# Altium schematic sheet acoustic_mic_gaze.SchDoc
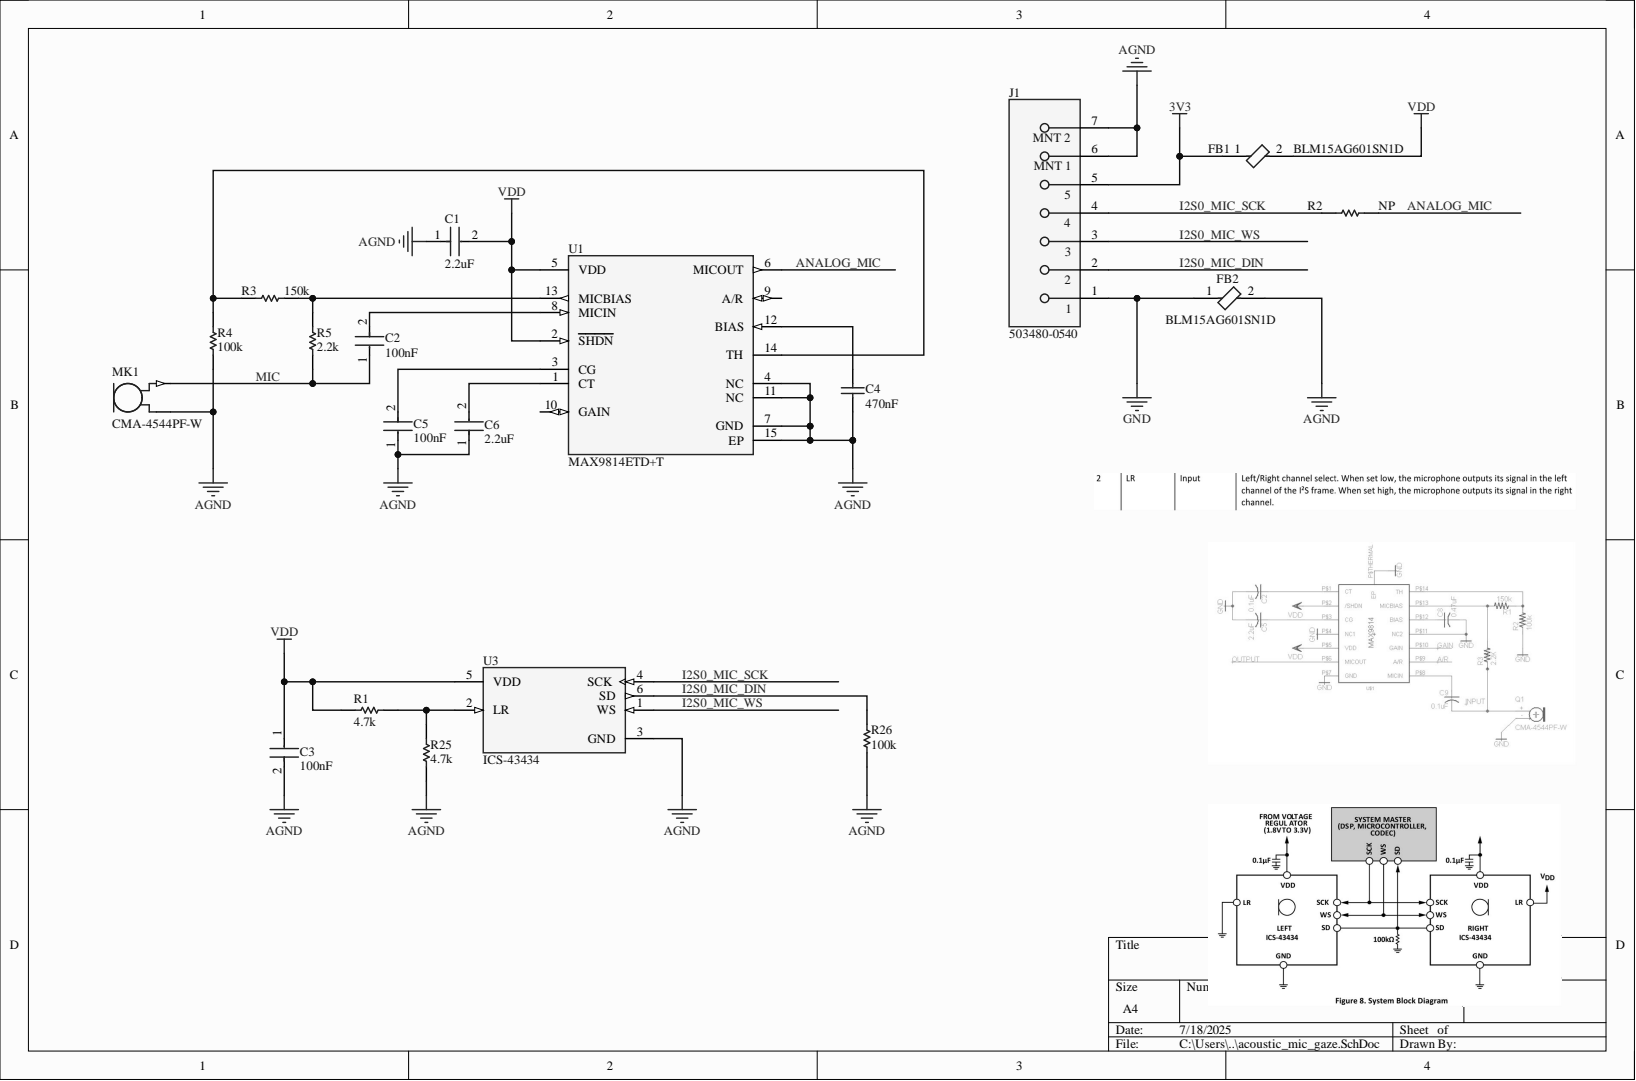
[diagram p0: acoustic_mic_gaze.SchDoc]

## Parts
C1  Capacitance: 2.2uF  [FP-GRM155-0_05-MFG]  (hidden comment: GRM155R61A225KE95D)
C2  value 100nF  [FP-GRM15-MFG]  (hidden comment: GRM155R71C104KA88D)
C3  Capacitance: 100nF  [FP-GRM15-MFG]  (hidden comment: GRM155R71C104KA88D)
C4  value 470nF  [CAPC1005X70X10LL20T00]  (hidden comment: TACK474M010QTA)
C5  value 100nF  [FP-GRM15-MFG]  (hidden comment: GRM155R71C104KA88D)
C6  Capacitance: 2.2uF  [FP-GRM155-0_05-IPC_C]  (hidden comment: GRM155R61A225KE95D)
FB1  BLM15AG601SN1D  [FP-BLM15-0_05-IPC_B]
FB2  BLM15AG601SN1D  [FP-BLM15-0_05-IPC_B]
J1  503480-0540  [FP-503480-0540-MFG]
MK1  CMA-4544PF-W  [MIC_CMA-4544PF-W]
R1  value 4.7k  [RESC1005X40X25LL05T10]  (hidden comment: CRCW04024K70FKEDHP)
R2  value NP  [RESC1005X40X25NL05T10]  (hidden comment: ERJ-U020R00X)
R3  value 150k  [RESC1005X40X25NL05T05]  (hidden comment: CRCW0402150KFKED)
R4  value 100k  [RESC1005X40X25NL05T10]  (hidden comment: RC0402FR-07100KL)
R5  value 2.2k  [RESC1005X40X25NL05T10]  (hidden comment: RC0402FR-072K2L)
R25  value 4.7k  [RESC1005X40X25LL05T10]  (hidden comment: CRCW04024K70FKEDHP)
R26  value 100k  [RESC1005X40X25NL05T10]  (hidden comment: RC0402FR-07100KL)
U1  MAX9814ETD+T  [FP-T1433-2-MFG]
U3  ICS-43434  [FP-ICS-43434-MFG]

## Nets
3V3: FB1.1 J1.5
AGND: C1.1 C3.2 C4.1 C5.1 C6.1 FB2.2 J1.6 J1.7 MK1.2 R4.2 R25.1 R26.2 U1.4(NC) U1.7(GND) U1.11(NC) U1.15(EP) U3.3(GND)
GND: FB2.1 J1.1
VDD: C1.2 C3.1 FB1.2 R1.2 U1.2(SHDN) U1.5(VDD) U3.5(VDD)
ANALOG_MIC: R2.2 U1.6(MICOUT)
I2S0_MIC_DIN: J1.2 R26.1 U3.6(SD)
I2S0_MIC_SCK: J1.4 R2.1 U3.4(SCK)
I2S0_MIC_WS: J1.3 U3.1(WS)
MIC: C2.1 MK1.1 R5.1
NetC2_2: C2.2 U1.8(MICIN)
NetC4_2: C4.2 U1.12(BIAS)
NetC5_2: C5.2 U1.3(CG)
NetC6_2: C6.2 U1.1(CT)
NetR1_1: R1.1 R25.2 U3.2(LR)
NetR3_1: R3.1 R5.2 U1.13(MICBIAS)
NetR3_2: R3.2 R4.1 U1.14(TH)

Not connected: U1 9(A/R) 10(GAIN)

## Library data of the parts
C1 C6 = CMP-06035-063009-1: CAP CER 2.2UF 10V X5R 0402; Material: Ceramic; Tolerance: 10%; Case Code (Imperial): 0402; Case Code (Metric): 1005; Case/Package: 0402; Component Kind: Standard; Depth: 0.5mm; Dielectric: X5R; Height: 0.5mm; Lead Free: Lead Free; Length: 1mm; Library Name: Altium Content Vault; Max Operating Temperature: 85°C; Min Operating Temperature: -55°C; Mount: Surface Mount; Package Quantity: 10000; Packaging: Tape and Reel; REACH SVHC: No SVHC; RoHS Compliant: Yes; Termination: SMD/SMT; Thickness: 0.022inch; Voltage Rating: 10V; Voltage Rating (DC): 10V; Weight: 0.000212oz; Width: 0.5mm
C2 C5 = CMP-2100-03641-2: CAP CER 0.1UF 16V X7R 0402; Manufacturer: Murata; Capacitance: 0.10μF; Material: Ceramic; Standoff Height: 0mm; Tolerance: 10%; Voltage Rating: 16Vdc; Category: Cap; Component Kind: Standard; ComponentLink2Description: Datasheet; ComponentLink2URL: https://search.murata.co.jp/Ceramy/image/img/A01X/G101/ENG/GRM155R71H471KA01-01A.pdf; Device Class L1: Passive Components; Device Class L2: Capacitors; Device Class L3: Ceramic Capacitors; Digikey Description: CAP CER 0.1UF 16V X7R 0402; Height: 0.55mm; Ipc Land Pattern Name: CAPC10050X219; Lead Free: yes; Library Name: Altium Content Vault; Manufacturer Part Number: GRM155R71C104KA88D; Mouser Description: 0402 0.1 uF 16V ±10 % Tolerance X7R SMT Multilayer Ceramic Capacitor; Octopart: https://octopart.com/grm155r71c104ka88d-murata-196880; Package: GRM15; RoHS: TRUE; Temperature: -55°C to +125°C; Temperature Characteristic: X7R; Temperature Range High: +125°C; Temperature Range Low: -55°C
C3 = CMP-2100-03641-2: CAP CER 0.1UF 16V X7R 0402; Manufacturer: Murata; Material: Ceramic; Standoff Height: 0mm; Tolerance: 10%; Value: 0.10μF; Voltage Rating: 16Vdc; Category: Cap; Component Kind: Standard; ComponentLink2Description: Datasheet; ComponentLink2URL: https://search.murata.co.jp/Ceramy/image/img/A01X/G101/ENG/GRM155R71H471KA01-01A.pdf; Device Class L1: Passive Components; Device Class L2: Capacitors; Device Class L3: Ceramic Capacitors; Digikey Description: CAP CER 0.1UF 16V X7R 0402; Height: 0.55mm; Ipc Land Pattern Name: CAPC10050X219; Lead Free: yes; Library Name: Altium Content Vault; Manufacturer Part Number: GRM155R71C104KA88D; Mouser Description: 0402 0.1 uF 16V ±10 % Tolerance X7R SMT Multilayer Ceramic Capacitor; Octopart: https://octopart.com/grm155r71c104ka88d-murata-196880; Package: GRM15; RoHS: TRUE; Temperature: -55°C to +125°C; Temperature Characteristic: X7R; Temperature Range High: +125°C; Temperature Range Low: -55°C
C4 = CMP-2008-00072-1: Manufacturer: AVX Interconnect / Elco; Component Kind: Standard; Library Name: Altium Content Vault; Part Number: TACK474M010QTA
FB1 FB2 = CMP-0686-00187-4: Chip Ferrite Bead, 0402, 600Ω @ 100MHz, 0.52Ω, 25%, 300mA; DC Resistance (DCR): 520mR; DC Resistance-Max: 600mR; Impedance: 600R; Material: Steel; Number of Circuits: 1; Shielding: Unshielded; Case Code (Imperial): 0402; Case Code (Metric): 1005; Case/Package: 0402; Component Kind: Standard; Core Material: Ferrite; Current Rating: 300mA; DC Current: 300mA; Depth: 0.55mm; Frequency: 100MHz; Height: 0.5mm; Lead Free: Lead Free; Length: 1mm; Library Name: Altium Content Vault; Max Current Rating: 300mA; Max DC Current: 300mA; Max Operating Temperature: 125°C; Military Standard: Not; Min Operating Temperature: -55°C; Number of Lines: 1; Number of Pins: 2; Package Quantity: 10000; Packaging: Tape and Reel; Radiation Hardening: No; REACH SVHC: No SVHC; RoHS Compliant: Yes; Series: BLM15AG_SN; Termination: SMD/SMT; Test Frequency: 100MHz; Tolerance: 25%; Width: 0.5mm
J1 = CMP-00257-52963780-1: 503480-0540 Connector FFC/FPC SKT 5 Position 0.5mm Solder Right Angle Surface Mount T/R; Manufacturer Lifecycle Status: ACTIVE; Actuator Material: Glass, Nylon, Polyamide; Current Rating: 500mA; Number of Circuits: 5; Pitch: 508µm; Plating: Gold; Voltage Rating: 50V; Wire/Cable Type: Straight; Component Kind: Standard; Contact Material: Bronze, Gold, Nickel; Contact Plating: Gold; Country of Origin: KR; Flammability Rating: UL94 V-0; Halogen Free: Low Halogen; Height: 2.07mm; Housing Material: Polymer; Library Name: Altium Content Vault; Lifecycle Status: Production; Max Operating Temperature: 85°C; Min Operating Temperature: -40°C; Mount: Surface Mount; NexarPartId: 52963780; Number of Contacts: 5; Number of Positions: 5; Number of Rows: 1; Orientation: Right Angle; RoHS: Compliant; Termination: Solder
MK1 = CMA-4544PF-W: 9.7 mm, Omnidirectional, PCB Mount, 3.0 Vdc, Electret Condenser Microphone; MF: Same Sky; Check_prices: https://www.snapeda.com/parts/CMA-4544PF-W/Same+Sky/view-part/?ref=eda; Component Kind: Standard; Library Name: CMA-4544PF-W.IntLib; MP: CMA-4544PF-W; Package: None; SnapEDA_Link: https://www.snapeda.com/parts/CMA-4544PF-W/Same+Sky/view-part/?ref=snap
R1 R25 = CMP-2002-03050-1: Manufacturer: Vishay Dale; Component Kind: Standard; Library Name: Altium Content Vault; Part Number: CRCW04024K70FKEDHP
R2 = CMP-2002-06457-1: Manufacturer: Panasonic; Component Kind: Standard; Library Name: Altium Content Vault; Part Number: ERJ-U020R00X
R3 = CMP-2002-08212-1: Manufacturer: Vishay Dale; Component Kind: Standard; Library Name: Altium Content Vault; Part Number: CRCW0402150KFKED
R4 R26 = CMP-2002-07343-1: Manufacturer: Yageo / Phycomp; Component Kind: Standard; Library Name: Altium Content Vault; Part Number: RC0402FR-07100KL
R5 = CMP-2002-07567-1: Manufacturer: Yageo / Phycomp; Component Kind: Standard; Library Name: Altium Content Vault; Part Number: RC0402FR-072K2L
U1 = CMP-05698-000003-1: IC AMP CLASS AB MONO 14TDFN; Load Impedance: 50R; Max Input Voltage: 5.5V; Max Output Current: 100mA; Max Power Dissipation: 1.481W; Min Supply Voltage: 2.7V; Number of Channels: 1; Operating Supply Current: 3.1mA; Power Supply Rejection Ratio (PSRR): 80dB; Voltage Gain: 60dB; Component Kind: Standard; Contact Plating: Tin; Height: 0.75mm; Lead Free: Lead Free; Length: 3.1mm; Library Name: Altium Content Vault; Max Operating Temperature: 85°C; Max Supply Voltage: 5.5V; Min Operating Temperature: -40°C; Mount: Surface Mount; Number of Pins: 14; Package Quantity: 1; Packaging: Tape and Reel; Radiation Hardening: No; REACH SVHC: No SVHC; Termination: SMD/SMT; Weight: 0.001764oz; Width: 3.1mm
U3 = CMP-03292-71346757-1: ICS-43434 Mic Omni-Directional -26dB 3.63V Rectangular Solder Pad T/R; Direction: Omnidirectional; Min Supply Voltage: 1.65V; Operating Supply Current: 550µA; Output Type: Digital; Component Kind: Standard; Height: 980µm; Length: 3.5mm; Library Name: Altium Content Vault; Lifecycle Status: Production; Max Length: 3.6mm; Max Operating Temperature: 85°C; Max Supply Voltage: 3.63V; Max Thickness: 1.08mm; Max Width: 2.75mm; Min Length: 3.4mm; Min Operating Temperature: -40°C; Min Thickness: 880µm; Min Width: 2.55mm; NexarPartId: 71346757; RoHS: Compliant; Schedule B: 8518100000; Width: 2.65mm
